# Altium schematic sheet 06_LMS7002M_RF.SchDoc(06_LMS7002M_RF)
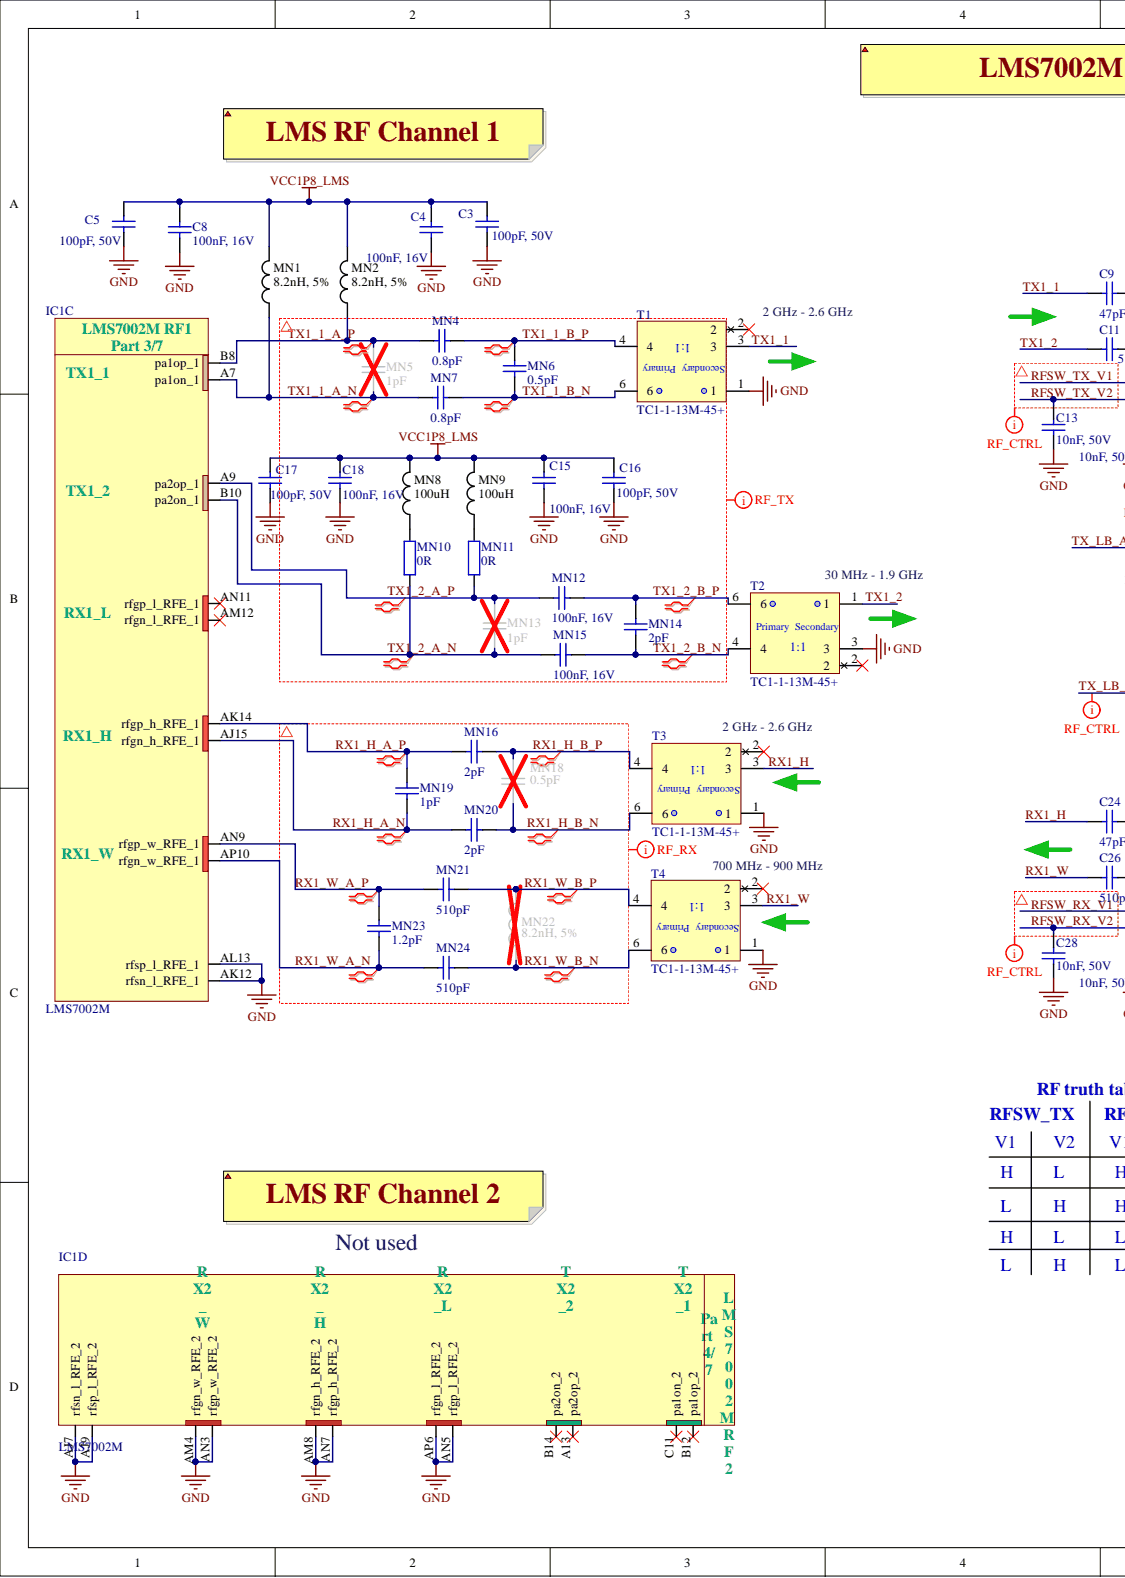
[diagram p0: 06_LMS7002M_RF.SchDoc, left]
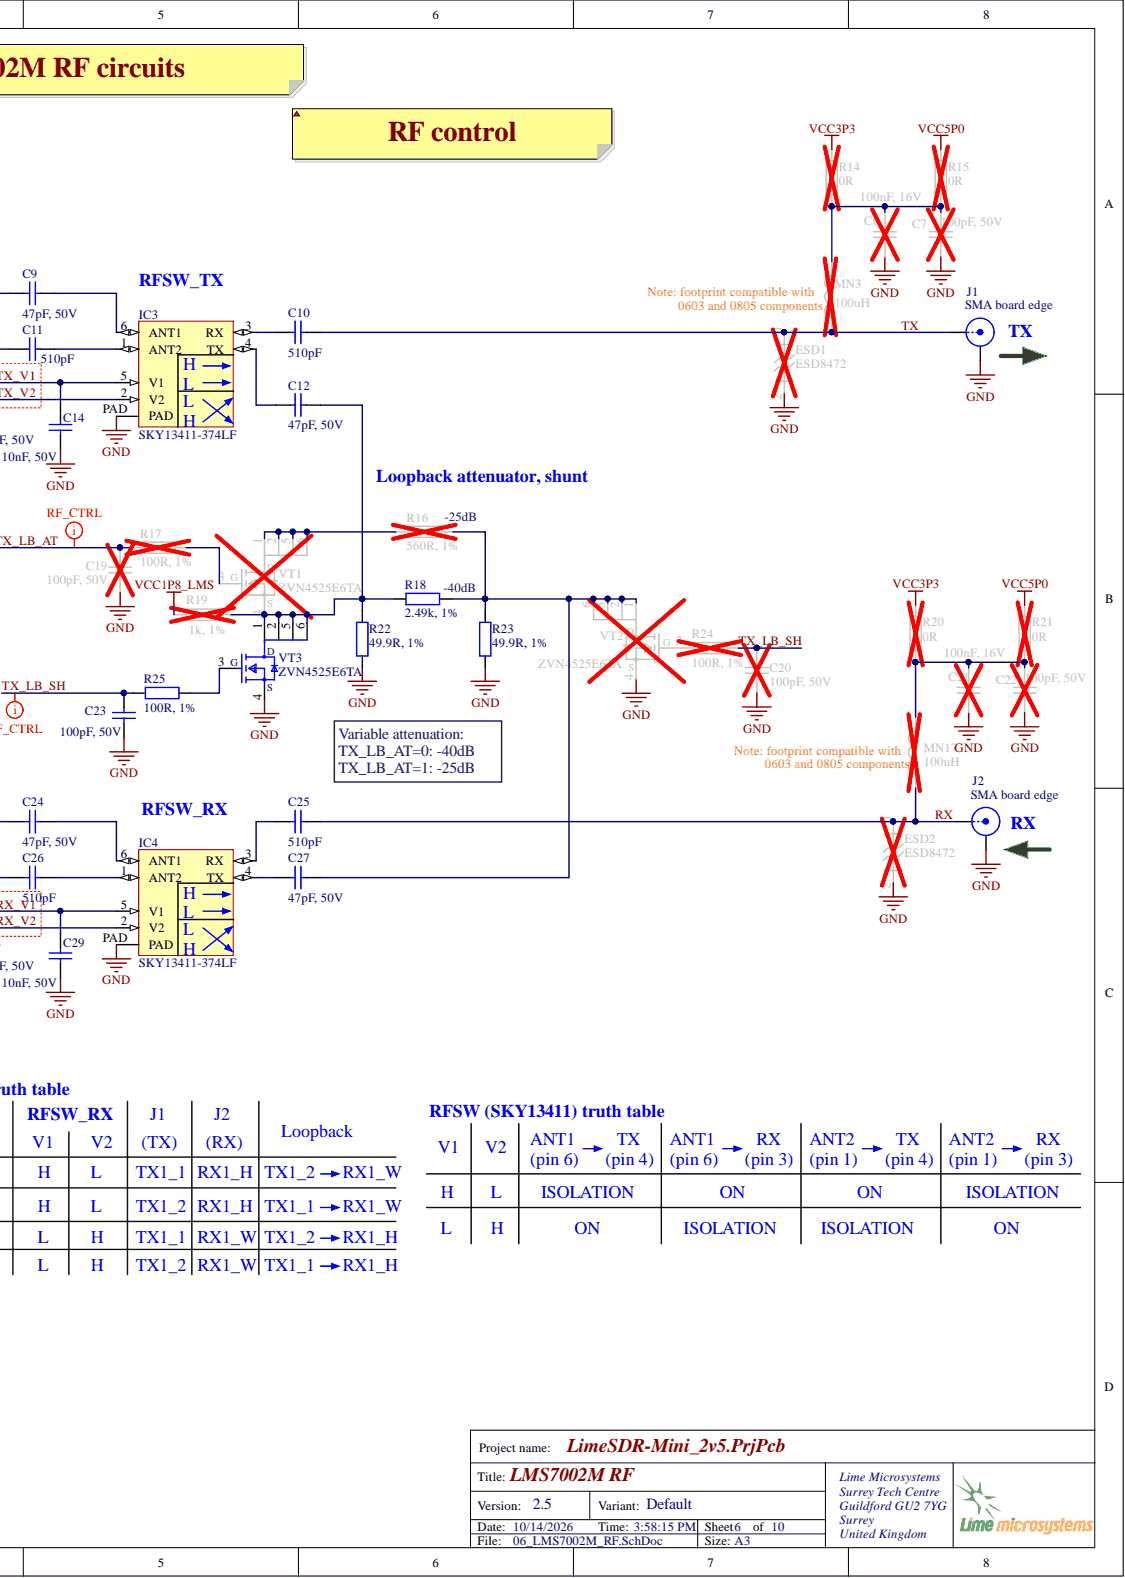
[diagram p1: 06_LMS7002M_RF.SchDoc, right]

## Parts
C3  100pF, 50V  Voltage - Rated: 50V  [CAP0402]
C4  100nF, 16V  [CAP0402]
C5  100pF, 50V  Voltage - Rated: 50V  [CAP0402]
C6  100nF, 16V  [CAP0402]
C7  100pF, 50V  Voltage - Rated: 50V  [CAP0402]
C8  100nF, 16V  [CAP0402]
C9  47pF, 50V  Voltage - Rated: 50V  [CAP0402]
C10  510pF  [CAP0402]
C11  510pF  [CAP0402]
C12  47pF, 50V  Voltage - Rated: 50V  [CAP0402]
C13  10nF, 50V  [CAP0402]
C14  10nF, 50V  Voltage Rating (DC): 50V  [CAP0402]
C15  100nF, 16V  [CAP0402]
C16  100pF, 50V  Voltage - Rated: 50V  [CAP0402]
C17  100pF, 50V  [CAP0402]
C18  100nF, 16V  [CAP0402]
C19  100pF, 50V  Voltage - Rated: 50V  [CAP0402]
C20  100pF, 50V  [CAP0402]
C21  100nF, 16V  [CAP0402]
C22  100pF, 50V  Voltage - Rated: 50V  [CAP0402]
C23  100pF, 50V  Voltage - Rated: 50V  [CAP0402]
C24  47pF, 50V  Voltage - Rated: 50V  [CAP0402]
C25  510pF  [CAP0402]
C26  510pF  [CAP0402]
C27  47pF, 50V  Voltage - Rated: 50V  [CAP0402]
C28  10nF, 50V  [CAP0402]
C29  10nF, 50V  Voltage Rating (DC): 50V  [CAP0402]
ESD1  ESD8472  [ESD8472_X3DFN2]
ESD2  ESD8472  [ESD8472_X3DFN2]
IC1  LMS7002M  [LMS7002M_v5]  (parts C,D)
IC3  SKY13411-374LF  [SKY13411-374LF]
IC4  SKY13411-374LF  [SKY13411-374LF]
J1  SMA board edge  Connector Style: SMA  [SMA_connector_board_edge_SM]
J2  SMA board edge  Connector Style: SMA  [SMA_connector_board_edge_SM]
MN1  8.2nH, 5%  [RES0402]
MN2  8.2nH, 5%  [RES0402]
MN3  100uH  [RES0805_0603]
MN4  0.8pF  [CAP0402]
MN5  1pF  [CAP0402]
MN6  0.5pF  [CAP0402]
MN7  0.8pF  [CAP0402]
MN8  100uH  [RES0805]
MN9  100uH  [RES0805]
MN10  0R  [RES0402]
MN11  0R  [RES0402]
MN12  100nF, 16V  [CAP0402]
MN13  1pF  [CAP0402]
MN14  2pF  [CAP0402]
MN15  100nF, 16V  [CAP0402]
MN16  2pF  [CAP0402]
MN17  100uH  [RES0805_0603]
MN18  0.5pF  [CAP0402]
MN19  1pF  [CAP0402]
MN20  2pF  [CAP0402]
MN21  510pF  [CAP0402]
MN22  8.2nH, 5%  [RES0402]
MN23  1.2pF  [CAP0402]
MN24  510pF  [CAP0402]
R14  0R  [RES0402]
R15  0R  [RES0402]
R16  560R, 1%  [RES0402]
R17  100R, 1%  [RES0402]
R18  2.49k, 1%  [RES0402]
R19  1k, 1%  [RES0402]
R20  0R  [RES0402]
R21  0R  [RES0402]
R22  49.9R, 1%  [RES0402]
R23  49.9R, 1%  [RES0402]
R24  100R, 1%  [RES0402]
R25  100R, 1%  [RES0402]
T1  TC1-1-13M-45+  [TC1-1-13MA+_JA4220-AL_]
T2  TC1-1-13M-45+  [TC1-1-13MA+_JA4220-AL_]
T3  TC1-1-13M-45+  [TC1-1-13MA+_JA4220-AL_]
T4  TC1-1-13M-45+  [TC1-1-13MA+_JA4220-AL_]
VT1  ZVN4525E6TA  [ZVN4525E6_NMOS_SOT23-6]
VT2  ZVN4525E6TA  [ZVN4525E6_NMOS_SOT23-6]
VT3  ZVN4525E6TA  [ZVN4525E6_NMOS_SOT23-6]

## Nets
GND: C3.2 C4.1 C5.2 C6.1 C7.2 C8.1 C13.1 C14.1 C15.1 C16.2 C17.2 C18.1 C19.2 C20.2 C21.1 C22.2 C23.2 C28.1 C29.1 ESD1.2 ESD2.2 IC1.AJ7(rfsn_l_RFE_2) IC1.AJ9(rfsp_l_RFE_2) IC1.AK12(rfsn_l_RFE_1) IC1.AL13(rfsp_l_RFE_1) IC1.AM4(rfgn_w_RFE_2) IC1.AM8(rfgn_h_RFE_2) IC1.AN3(rfgp_w_RFE_2) IC1.AN5(rfgp_l_RFE_2) IC1.AN7(rfgp_h_RFE_2) IC1.AP6(rfgn_l_RFE_2) IC3.PAD IC4.PAD J1.2 J2.2 R22.1 R23.1 T1.1 T2.3 T3.1 T4.1 VT2.4 VT3.4
VCC1P8_LMS: C3.1 C4.2 C5.1 C8.2 C15.2 C16.1 C17.1 C18.2 MN1.2 MN2.2 MN8.2 MN9.2 R19.2
VCC3P3: R14.1 R20.1
VCC5P0: R15.1 R21.1
RFSW_RX_V1: C29.2 IC4.5(V1)
RFSW_RX_V2: C28.2 IC4.2(V2)
RFSW_TX_V1: C14.2 IC3.5(V1)
RFSW_TX_V2: C13.2 IC3.2(V2)
RX: C25.2 ESD2.1 J2.1 MN17.1
RX1_H: C24.2 T3.3
RX1_H_A_N: IC1.AJ15(rfgn_h_RFE_1) MN19.1 MN20.2
RX1_H_A_P: IC1.AK14(rfgp_h_RFE_1) MN16.2 MN19.2
RX1_H_B_N: MN18.1 MN20.1 T3.6
RX1_H_B_P: MN16.1 MN18.2 T3.4
RX1_W: C26.2 T4.3
RX1_W_A_N: IC1.AP10(rfgn_w_RFE_1) MN23.1 MN24.2
RX1_W_A_P: IC1.AN9(rfgp_w_RFE_1) MN21.2 MN23.2
RX1_W_B_N: MN22.1 MN24.1 T4.6
RX1_W_B_P: MN21.1 MN22.2 T4.4
TX: C10.2 ESD1.1 J1.1 MN3.1
TX1_1: C9.2 T1.3
TX1_1_A_N: IC1.A7(pa1on_1) MN1.1 MN5.1 MN7.2
TX1_1_A_P: IC1.B8(pa1op_1) MN2.1 MN4.1 MN5.2
TX1_1_B_N: MN6.2 MN7.1 T1.6
TX1_1_B_P: MN4.2 MN6.1 T1.4
TX1_2: C11.2 T2.1
TX1_2_A_N: IC1.B10(pa2on_1) MN10.1 MN13.2 MN15.1
TX1_2_A_P: IC1.A9(pa2op_1) MN11.1 MN12.2 MN13.1
TX1_2_B_N: MN14.1 MN15.2 T2.4
TX1_2_B_P: MN12.1 MN14.2 T2.6
TX_LB_AT: C19.1 R17.2
TX_LB_SH: C20.1 C23.1 R24.1 R25.2
NetC6_2: C6.2 C7.1 MN3.2 R14.2 R15.2
NetC9_1: C9.1 IC3.6(ANT1)
NetC10_1: C10.1 IC3.3(RX)
NetC11_1: C11.1 IC3.1(ANT2)
NetC12_1: C12.1 IC3.4(TX)
NetC12_2: C12.2 R18.1 R19.1 R22.2 VT1.4 VT3.1 VT3.2 VT3.5 VT3.6
NetC21_2: C21.2 C22.1 MN17.2 R20.2 R21.2
NetC24_1: C24.1 IC4.6(ANT1)
NetC25_1: C25.1 IC4.3(RX)
NetC26_1: C26.1 IC4.1(ANT2)
NetC27_1: C27.1 IC4.4(TX)
NetC27_2: C27.2 R16.1 R18.2 R23.2 VT2.1 VT2.2 VT2.5 VT2.6
NetMN8_1: MN8.1 MN10.2
NetMN9_1: MN9.1 MN11.2
NetR16_2: R16.2 VT1.1 VT1.2 VT1.5 VT1.6
NetR17_1: R17.1 VT1.3
NetR24_2: R24.2 VT2.3
NetR25_1: R25.1 VT3.3
N00120:
N00121:
N00122:
N00123:

Not connected: IC1 A13(pa2op_2) AM12(rfgn_l_RFE_1) AN11(rfgp_l_RFE_1) B12(pa1op_2) B14(pa2on_2) C11(pa1on_2); T1 2; T2 2; T3 2; T4 2

## Library data of the parts
C3 C5 C7 C16 C17 C19 C20 C22 C23 = Cap_0402: Part Description: 100pF ±5% 50V C0G, NP0 Ceramic Capacitor -55°C ~ 125°C Surface Mount, MLCC 0402 (1005 Metric) 0.039" L x 0.020" W (1.00mm x 0.50mm); Manufacturer Part Number 1: CC0402JRNPO9BN101; Manufacturer: Yageo; Tolerance: ±5%; Temperature Coefficient: C0G, NP0; Value: 100pF, 50V; Thickness (Max): 0.022" (0.55mm); Supplier Part Number 1: 311-1024-1-ND; Supplier 1: Digi-Key; Size / Dimension: 0.039" L x 0.020" W (1.00mm x 0.50mm); RoHS: RoHS Compliant; Ratings: -; Packaging: Cut Tape (CT); Package / Case: 0402 (1005 Metric); Operating Temperature: -55°C ~ 125°C; Mounting Type: Surface Mount, MLCC; Lead Style: -; Lead Spacing: -; Height - Seated (Max): -; Features: -; Component Kind: Standard; Category: Capacitors; Capacitance: 100pF; Applications: General Purpose; Library Name: LimeMicroAltiumLib_schLib.SchLib
  C17 C20: Voltage - Rated: 50V
C4 C6 C8 C15 C18 C21 = Cap_0402: Part Description: 0.10µF ±10% 16V X7R Ceramic Capacitor -55°C ~ 125°C Surface Mount, MLCC 0402 (1005 Metric) 0.039" L x 0.020" W (1.00mm x 0.50mm); Manufacturer Part Number 1: GRM155R71C104KA88D; Manufacturer: Murata Electronics; Type: General Purpose MLCC; Tolerance: 10 %; Temperature Coefficient / Code: +/- 15 %; Dielectric: X7R; Case Width: 0.5 mm; Case Length: 1 mm; Capacitance: 0.1 uF; Voltage Rating DC: 16 V; Value: 100nF, 16V; Termination Style: SMD/SMT; Supplier Part Number 1: 81-GRM155R71C104KA88; Supplier 1: Mouser; Standard Pack Qty: 10000; Series: GRM; RoHS - Mouser: Y; RoHS: RoHS Compliant; Reeling: True; Product Category: Low & Medium Capacitance SMD Ceramic Chip Capacitors; Product: General Type MLCCs; Packaging: Reel; Package / Case: 0402 (1005 metric); Operating Temperature Range: - 55 C to + 125 C; Minimum Order Quantity: 1; Minimum Operating Temperature: - 55 C; Maximum Operating Temperature: + 125 C; Manufacturer Part Number: GRM155R71C104KA88D; Component Kind: Standard; Category: Multilayer Ceramic Capacitors MLCC - SMD/SMT; Case Height: 0.5 mm; Case Code - mm: 1005; Case Code - in: 0402; Brand: Murata Electronics; Library Name: LimeMicroAltiumLib_schLib.SchLib
C9 C12 C24 C27 = Cap_0402: Part Description: 47pF ±1% 50V C0G, NP0 Ceramic Capacitor -55°C ~ 125°C Surface Mount, MLCC 0402 (1005 Metric) 0.039" L x 0.020" W (1.00mm x 0.50mm); Manufacturer Part Number 1: GRM1555C1H470FA01D; Manufacturer: TDK Corporation; Tolerance: ±1%; Temperature Coefficient: C0G, NP0; Value: 47pF, 50V; Thickness (Max): 0.022" (0.55mm); Supplier Part Number 1: 490-6241-1-ND; Supplier 1: Digi-Key; Size / Dimension: 0.039" L x 0.020" W (1.00mm x 0.50mm); RoHS: RoHS Compliant; Ratings: -; Packaging: Cut Tape (CT); Package / Case: 0402 (1005 Metric); Operating Temperature: -55°C ~ 125°C; Mounting Type: Surface Mount, MLCC; Lead Style: -; Lead Spacing: -; Height - Seated (Max): -; Features: -; Component Kind: Standard; Category: Capacitors; Capacitance: 47pF; Applications: General Purpose; Library Name: LimeMicroAltiumLib_schLib.SchLib
C10 C11 C25 C26 MN21 MN24 = Cap_0402: Part Description: 510pF ±5% 50V C0G, NP0 Ceramic Capacitor -55°C ~ 125°C Surface Mount, MLCC 0402 (1005 Metric) 0.039" L x 0.020" W (1.00mm x 0.50mm); Manufacturer Part Number 1: GRM1555C1H511JA01D; Manufacturer: Murata Electronics North America; Voltage - Rated: 50V; Tolerance: ±5%; Temperature Coefficient: C0G, NP0; Value: 510pF; Thickness (Max): 0.022" (0.55mm); Supplier Part Number 1: 490-3237-1-ND; Supplier 1: Digi-Key; Size / Dimension: 0.039" L x 0.020" W (1.00mm x 0.50mm); RoHS: RoHS Compliant; Ratings: -; Packaging: Cut Tape (CT); Package / Case: 0402 (1005 Metric); Operating Temperature: -55°C ~ 125°C; Mounting Type: Surface Mount, MLCC; Lead Style: -; Lead Spacing: -; Height - Seated (Max): -; Features: -; Component Kind: Standard; Category: Capacitors; Capacitance: 510pF; Applications: General Purpose; Library Name: LimeMicroAltiumLib_schLib.SchLib
C13 C14 C28 C29 = Cap_0402: Part Description: 10000pF ±10% 50V Ceramic Capacitor X7R 0402 (1005 Metric); Manufacturer Part Number 1: GRM155R71H103KA88D; Manufacturer: Murata; Voltage: 25V; Tolerance: 10%; Material: Ceramic; Dielectric: X7R; Width: 0.02inch; Voltage Rating: 50V; value: 10nF, 50V; Thickness: 0.022inch; Termination: SMD/SMT; Supplier Part Number 1: 490-4516-1-ND; Supplier 1: Digi-Key; Stock 1: 8941817; RoHS: Yes; REACH SVHC: No SVHC; Radiation Hardening: No; Pricing 1: 1=0,10000, 10=0,03800, 100=0,01690, 500=0,01208, 1000=0,00949, 2500=0,00862, 5000=0,00794 (USD); Packaging: Tape and Reel; Package Quantity: 1; Number of Pins: 2; Mount: Surface Mount; Min Operating Temperature: -55°C; Max Operating Temperature: 125°C; Length: 1mm; Lead Free: Lead Free; Height: 0.5mm; Depth: 0.5mm; Component Kind: Standard; Case Code (Metric): 1005; Case Code (Imperial): 0402; Case/Package: 0402; Capacitance: 10nF; Library Name: LimeFPGAboard_schLib.SchLib
  C13 C28: Voltage Rating (DC): 50V
ESD1 ESD2 = ESD8472: Part Description: TVS DIODE 5.3VWM 14VC X3DFN2; Manufacturer Part Number 1: ESD8472MUT5G; Manufacturer: ON Semiconductor; Voltage - Reverse Standoff (Typ): 5.3V (Max); Voltage - Clamping (Max) @ Ipp: 14V; Voltage - Breakdown (Min): 7V; Power Line Protection: No; Current - Peak Pulse (10/1000µs): 3A (8/20µs); Capacitance @ Frequency: 0.2pF @ 1MHz; Type: Zener; Supplier Part Number 1: ESD8472MUT5GOSCT-ND; Supplier Device Package: 2-X3DFN (0.62x0.32); Supplier 1: Digi-Key; Stock 1: 2295; RoHS: RoHS Compliant; Pricing 1: 1=0.39, 10=0.315, 100=0.2142, 500=0.16068, 1000=0.12051 (USD); Power - Peak Pulse: -; Part Status: Active; Packaging: Cut Tape (CT); Package / Case: 2-XFDFN; Operating Temperature: -55°C ~ 150°C (TJ); Mounting Type: Surface Mount; ComponentLink1URL: http://www.onsemi.com/pub/Collateral/ESD8472-D.PDF; ComponentLink1Description: http://www.onsemi.com/pub/Collateral/ESD8472-D.PDF; Component Kind: Standard; Category: Circuit Protection; Applications: Automotive, RF Antenna; Library Name: LimeMicroAltiumLib_schLib.SchLib
IC1 = LMS7002Mr4: Schematic symbol revision 4. Default footprint - 0.31mm pad; Part Description: IC FPRF Transceiver LMS7002M; 261 pin aQFN; Manufacturer: Lime Microsystems; Supplier Part Number 1: LMS7002M; Supplier 1: Lime Microsystems; Manufacturer Part Number 1: LMS7002M; Library Name: LimeMicroAltiumLib_schLib_r04.SchLib; Component Kind: Standard
IC3 IC4 = SKY13411-374LF: Part Description: RF Switch IC 802.11a/b/g/n DPDT 50 Ohm 6-MLPD (1.5x1.5); Manufacturer: Skyworks Solutions Inc.; Topology: Reflective; RF Type: 802.11a/b/g/n; P1dB: 30dBm (typ) IP1dB; Isolation @ Frequency: 14dB @ 6GHz (typ); Insertion Loss @ Frequency: 0.9dB @ 6GHz; Impedance: 50 Ohm; IIP3: 50dBm (typ); Frequency - Lower: 100MHz; Circuit: DPDT; Voltage - Supply: -; Supplier Part Number 1: 863-1455-1-ND; Supplier Device Package: 6-MLPD (1.5x1.5); Supplier 1: Digi-Key; Stock 1: 18782; RoHS: RoHS Compliant; Pricing 1: 1=0.88, 10=0.782, 100=0.6099, 500=0.50382, 1000=0.39776 (USD); Part Status: Active; Packaging: Cut Tape (CT); Package / Case: 6-XFDFN Exposed Pad; Operating Temperature: -40°C ~ 85°C; Manufacturer Part Number 1: SKY13411-374LF; Features: -; ComponentLink1URL: http://www.skyworksinc.com/uploads/documents/201665B.pdf; ComponentLink1Description: http://www.skyworksinc.com/uploads/documents/201665B.pdf; Component Kind: Standard; Category: RF/IF and RFID; Library Name: LimeMicroAltiumLib_schLib.SchLib
J1 J2 = RF_IF_connector: CONN SMA JACK STR 50OHM EDGE MNT; Part Description: SMA Connector Jack, Female Socket Board Edge, End Launch Solder; Manufacturer Part Number 1: CON-SMA-EDGE-S; Manufacturer: RF Solutions; Shield Termination: Solder; Connector Type: Jack, Female Socket; Voltage Rating: -; Supplier Part Number 1: CON-SMA-EDGE-S-ND; Supplier 1: Digi-Key; Stock 1: 1751; RoHS: RoHS Compliant; Pricing 1: 1=2.1 (USD); Part Status: Active; Packaging: -; Operating Temperature: -; Mounting Type: Board Edge, End Launch; Mounting Feature: -; Mating Cycles: -; Insertion Loss: -; Ingress Protection: -; Includes: -; Impedance: -; Housing Color: -; Frequency - Max: -; Features: -; Fastening Type: Threaded; Dielectric Material: -; Contact Termination: Solder; ComponentLink1URL: http://media.digikey.com/pdf/Data%20Sheets/RF%20Solutions%20PDFs/CON-SMA-EDGE.pdf; ComponentLink1Description: http://media.digikey.com/pdf/Data%20Sheets/RF%20Solutions%20PDFs/CON-SMA-EDGE.pdf; Component Kind: Standard; Center Contact Plating: -; Center Contact Material: -; Category: Connectors, Interconnects; Cable Group: -; Body Material: -; Body Finish: -; Library Name: LimeMicroAltiumLib_schLib.SchLib
MN1 MN2 MN22 = Inductor_0603: Part Description: Surface Mount High Frequency Inductor, 0402HP Series, 8.2 nH, ± 5%, 0402 [1005 Metric], 5.4 GHz; Manufacturer Part Number 1: 0402HP-8N2XJLU; Manufacturer: COILCRAFT; SVHC: No SVHC (16-Jun-2014); Self Resonant Frequency Min: 5.4; Inductor Construction: Wirewound; Inductor Case Style: 0402 [1005 Metric]<!--FANDB--><div class="features-and-benefits-container"></div>; Inductance: 8.2; High Frequency Inductor Case: 0402 [1005 Metric]; DC Resistance Max: 0.065; DC Current Rating: 1.5; Value: 8.2nH, 5%; Supplier Part Number 1: 2285826; Supplier 1: Farnell; RoHS: Y-EX; Product Range: COILCRAFT - 0402; Packaging: Cut Tape; Inductance Tolerance: ± 5%; Component Kind: Standard; Library Name: LimeMicroAltiumLib_schLib.SchLib
MN3 MN8 MN9 MN17 = Inductor_0603: Part Description: FIXED IND 100UH 2MA 3.1 OHM SMD; Manufacturer Part Number 1: MLF2012C101KT000; Manufacturer: TDK Corporation; Tolerance: ±10%; Shielding: Shielded; Q @ Freq: 25 @ 1MHz; Material - Core: Ferrite; Inductance: 100µH; Frequency - Test: 1MHz; Frequency - Self Resonant: 7MHz; DC Resistance (DCR): 3.1 Ohm Max; Current Rating: 2mA; Value: 100uH; Type: Multilayer; Supplier Part Number 1: 445-1071-1-ND; Supplier Device Package: 0805 (2012 Metric); Supplier 1: Digi-Key; Size / Dimension: 0.079" L x 0.049" W (2.00mm x 1.25mm); RoHS: RoHS Compliant; Packaging: Cut Tape (CT); Package / Case: 0805 (2012 Metric); Operating Temperature: -40°C ~ 85°C; Mounting Type: Surface Mount; Height - Seated (Max): 0.057" (1.45mm); Current - Saturation: -; Component Kind: Standard; Category: Inductors, Coils, Chokes; Library Name: LimeMicroAltiumLib_schLib.SchLib
MN4 MN7 = Cap_0402: Part Description: 0.80pF ±0.1pF 50V C0G, NP0 Ceramic Capacitor -55°C ~ 125°C Surface Mount, MLCC 0402 (1005 Metric) 0.039" L x 0.020" W (1.00mm x 0.50mm); Manufacturer Part Number 1: GRM1555C1HR80BA01D; Manufacturer: Murata Electronics North America; Voltage - Rated: 50V; Tolerance: ±0.1pF; Temperature Coefficient: C0G, NP0; Capacitance: 0.80pF; Value: 0.8pF; Thickness (Max): 0.022" (0.55mm); Supplier Part Number 1: 490-6269-1-ND; Supplier 1: Digi-Key; Size / Dimension: 0.039" L x 0.020" W (1.00mm x 0.50mm); RoHS: RoHS Compliant; Ratings: -; Packaging: Cut Tape (CT); Package / Case: 0402 (1005 Metric); Operating Temperature: -55°C ~ 125°C; Mounting Type: Surface Mount, MLCC; Lead Style: -; Lead Spacing: -; Height - Seated (Max): -; Features: -; Component Kind: Standard; Category: Capacitors; Applications: General Purpose; Library Name: LimeMicroAltiumLib_schLib.SchLib
MN5 MN13 MN19 = Cap_0402: Part Description: 1pF ±0.1pF 50V C0G, NP0 Ceramic Capacitor -55°C ~ 125°C Surface Mount, MLCC 0402 (1005 Metric) 0.039" L x 0.020" W (1.00mm x 0.50mm); Manufacturer Part Number 1: C1005C0G1H010B050BA; Manufacturer: TDK Corporation; Voltage - Rated: 50V; Tolerance: ±0.1pF; Temperature Coefficient: C0G, NP0; Value: 1pF; Thickness (Max): 0.022" (0.55mm); Supplier Part Number 1: 445-4854-6-ND; Supplier 1: Digi-Key; Size / Dimension: 0.039" L x 0.020" W (1.00mm x 0.50mm); RoHS: RoHS Compliant; Ratings: -; Packaging: Digi-Reel®; Package / Case: 0402 (1005 Metric); Operating Temperature: -55°C ~ 125°C; Mounting Type: Surface Mount, MLCC; Lead Style: -; Lead Spacing: -; Height - Seated (Max): -; Features: -; Component Kind: Standard; Category: Capacitors; Capacitance: 1pF; Applications: General Purpose; Library Name: LimeMicroAltiumLib_schLib.SchLib
MN6 MN18 = Cap_0402: Part Description: 0.50pF ±0.25pF 50V C0G, NP0 Ceramic Capacitor -55°C ~ 125°C Surface Mount, MLCC 0402 (1005 Metric) 0.039" L x 0.020" W (1.00mm x 0.50mm); Manufacturer Part Number 1: CC0402CRNPO9BNR50; Manufacturer: Yageo; Voltage - Rated: 50V; Tolerance: ±0.25pF; Temperature Coefficient: C0G, NP0; Capacitance: 0.50pF; Value: 0.5pF; Thickness (Max): 0.022" (0.55mm); Supplier Part Number 1: 311-1001-1-ND; Supplier 1: Digi-Key; Size / Dimension: 0.039" L x 0.020" W (1.00mm x 0.50mm); RoHS: RoHS Compliant; Ratings: -; Packaging: Cut Tape (CT); Package / Case: 0402 (1005 Metric); Operating Temperature: -55°C ~ 125°C; Mounting Type: Surface Mount, MLCC; Lead Style: -; Lead Spacing: -; Height - Seated (Max): -; Features: -; Component Kind: Standard; Category: Capacitors; Applications: General Purpose; Library Name: LimeMicroAltiumLib_schLib.SchLib
MN10 MN11 R14 R15 R20 R21 = Res_0402: Part Description: RES SMD 0.0OHM JUMPER 1/16W 0402; Manufacturer Part Number 1: RC0402JR-070RL; Manufacturer: Yageo; Tolerance: Jumper; Resistance (Ohms): 0.0; Power (Watts): 0.063W, 1/16W; Value: 0R; Temperature Coefficient: -; Supplier Part Number 1: 311-0.0JRTR-ND; Supplier Device Package: 0402; Supplier 1: Digi-Key; Size / Dimension: 0.039" L x 0.020" W (1.00mm x 0.50mm); RoHS: RoHS Compliant; Packaging: Tape & Reel (TR); Package / Case: 0402 (1005 Metric); Operating Temperature: -55°C ~ 155°C; Number of Terminations: 2; Height: 0.016" (0.40mm); Features: Moisture Resistant; Composition: Thick Film; Component Kind: Standard; Category: Resistors; Library Name: LimeFPGAboard_schLib.SchLib
MN12 MN15 = Cap_0402: Part Description: 0.10µF ±10% 16V X7R Ceramic Capacitor -55°C ~ 125°C Surface Mount, MLCC 0402 (1005 Metric) 0.039" L x 0.020" W (1.00mm x 0.50mm); Manufacturer Part Number 1: GRM155R71C104KA88D; Manufacturer: Murata Electronics; Tolerance: 10 %; Temperature Coefficient / Code: +/- 15 %; Dielectric: X7R; Case Width: 0.5 mm; Case Length: 1 mm; Capacitance: 0.1 uF; Voltage Rating DC: 16 V; Value: 100nF, 16V; Type: General Purpose MLCC; Termination Style: SMD/SMT; Supplier Part Number 1: 81-GRM155R71C104KA88; Supplier 1: Mouser; Standard Pack Qty: 10000; Series: GRM; RoHS - Mouser: Y; RoHS: RoHS Compliant; Reeling: True; Product Category: Low & Medium Capacitance SMD Ceramic Chip Capacitors; Product: General Type MLCCs; Packaging: Reel; Package / Case: 0402 (1005 metric); Operating Temperature Range: - 55 C to + 125 C; Minimum Order Quantity: 1; Minimum Operating Temperature: - 55 C; Maximum Operating Temperature: + 125 C; Manufacturer Part Number: GRM155R71C104KA88D; Component Kind: Standard; Category: Multilayer Ceramic Capacitors MLCC - SMD/SMT; Case Height: 0.5 mm; Case Code - mm: 1005; Case Code - in: 0402; Brand: Murata Electronics; Library Name: LimeMicroAltiumLib_schLib.SchLib
MN14 MN16 MN20 = Cap_0402: Part Description: SMD Multilayer Ceramic Capacitor, U Series, 2 pF, ± 0.1pF, C0G / NP0, 50 V, 0402 [1005 Metric]; Manufacturer Part Number 1: 04025U2R0BAT2A; Manufacturer: AVX; Voltage Rating: 50; SVHC: No SVHC (17-Dec-2014); Product Range: U Series; Dielectric Characteristic: C0G / NP0; Ceramic Capacitor Case: 0402 [1005 Metric]; Capacitance Tolerance ±: ± 0.1; Capacitance: 2; Value: 2pF; Supplier Part Number 1: 2332536; Supplier 1: Farnell; RoHS: YES; Packaging: Cut Tape; Operating Temperature Min: -55; Operating Temperature Max: 125; MSL: MSL 1 - Unlimited; Component Kind: Standard; Capacitance Tolerance: ± 0.1; Library Name: LimeMicroAltiumLib_schLib.SchLib
MN23 = Cap_0402: Part Description: '1.2pF ±0.25pF 50V C0G, NP0 Ceramic Capacitor -55°C ~ 125°C Surface Mount, MLCC 0402 (1005 Metric) 0.039" L x 0.020" W (1.00mm x 0.50mm); Manufacturer Part Number 1: GRM1555C1H1R2CA01D; Manufacturer: Murata Electronics North America; Voltage - Rated: 50V; Tolerance: ±0.25pF; Temperature Coefficient: C0G, NP0; Value: 1.2pF; Thickness (Max): 0.022" (0.55mm); Supplier Part Number 1: 490-5927-1-ND; Supplier 1: Digi-Key; Size / Dimension: 0.039" L x 0.020" W (1.00mm x 0.50mm); RoHS: RoHS Compliant; Ratings: -; Packaging: Cut Tape (CT); Package / Case: 0402 (1005 Metric); Operating Temperature: -55°C ~ 125°C; Mounting Type: Surface Mount, MLCC; Lead Style: -; Lead Spacing: -; Height - Seated (Max): -; Features: -; Component Kind: Standard; Category: Capacitors; Capacitance: 1.2pF; Applications: General Purpose; Library Name: LimeMicroAltiumLib_schLib.SchLib
R16 = Res_0402: Part Description: RES SMD 560 OHM 1% 1/16W 0402; Manufacturer Part Number 1: CRCW0402560RFKED; Manufacturer: Vishay Dale; Temperature Coefficient: ±100ppm/°C; Resistance (Ohms): 560; Power (Watts): 0.063W, 1/16W; Tolerance: ±1%; Supplier Part Number 1: 541-560LCT-ND; Supplier Device Package: 0402 (1005 Metric); Supplier 1: Digi-Key; Size / Dimension: 0.039" L x 0.020" W (1.00mm x 0.50mm); RoHS: RoHS Compliant; Packaging: Cut Tape (CT); Package / Case: 0402 (1005 Metric); Operating Temperature: -55°C ~ 155°C; Number of Terminations: 2; Height: 0.016" (0.40mm); Features: Automotive AEC-Q200; Composition: Thick Film; Component Kind: Standard; Category: Resistors; Library Name: LimeMicroAltiumLib_schLib.SchLib
R17 R24 R25 = Res_0402: Part Description: RES SMD 100 OHM 1% 1/16W 0402; Manufacturer Part Number 1: RMCF0402FT100R; Manufacturer: Stackpole Electronics Inc.; Temperature Coefficient: ±100ppm/°C; Value: 100R, 1%; Tolerance: ±1%; Supplier Part Number 1: RMCF0402FT100RDKR-ND; Supplier 1: Digi-Key; Package / Case: 0402 (1005 Metric); Composition: Thick Film; Component Kind: Standard; Category: Resistors; Library Name: LimeFPGAboard_schLib.SchLib
R18 = Res_0402: Part Description: RES SMD 2.49K OHM 1% 1/16W 0402; Manufacturer Part Number 1: RC0402FR-072K49L; Manufacturer: Yageo; Temperature Coefficient: ±100ppm/°C; Power (Watts): 0.063W, 1/16W; Value: 2.49k, 1%; Tolerance: ±1%; Supplier Part Number 1: 311-2.49KLRDKR-ND; Supplier Device Package: 0402; Supplier 1: Digi-Key; Size / Dimension: 0.039" L x 0.020" W (1.00mm x 0.50mm); RoHS: RoHS Compliant; Resistance (Ohms): 2.49k; Packaging: Digi-Reel®; Package / Case: 0402 (1005 Metric); Operating Temperature: -55°C ~ 155°C; Number of Terminations: 2; Height: 0.016" (0.40mm); Features: Moisture Resistant; Composition: Thick Film; Component Kind: Standard; Category: Resistors; Library Name: LimeMicroAltiumLib_schLib.SchLib
R19 = Res_0402: Part Description: RES SMD 1K OHM 1% 1/16W 0402; Manufacturer Part Number 1: RMCF0402FT1K00; Manufacturer: Stackpole Electronics Inc; Temperature Coefficient: ±100ppm/°C; Power (Watts): 0.063W, 1/16W; Value: 1k, 1%; Tolerance: ±1%; Supplier Part Number 1: RMCF0402FT1K00TR-ND; Supplier Device Package: 0402; Supplier 1: Digi-Key; Size / Dimension: 0.039" L x 0.020" W (1.00mm x 0.50mm); RoHS: RoHS Compliant; Resistance (Ohms): 1k; Packaging: Tape & Reel (TR); Package / Case: 0402 (1005 Metric); Operating Temperature: -55°C ~ 155°C; Number of Terminations: 2; Height: 0.016" (0.40mm); Features: -; Composition: Thick Film; Component Kind: Standard; Category: Resistors; Library Name: LimeMicroAltiumLib_schLib.SchLib
R22 R23 = Res_0402: Part Description: RES SMD 49.9 OHM 1% 1/16W 0402; Manufacturer Part Number 1: RC1005F49R9CS; Manufacturer: Samsung Electro-Mechanics America, Inc.; Temperature Coefficient: ±100ppm/°C; Resistance (Ohms): 49.9; Power (Watts): 0.063W, 1/16W; Value: 49.9R, 1%; Tolerance: ±1%; Supplier Part Number 1: 1276-3469-6-ND; Supplier Device Package: 0402 (1005 Metric); Supplier 1: Digi-Key; Size / Dimension: 0.039" L x 0.020" W (1.00mm x 0.50mm); RoHS: RoHS Compliant; Packaging: Digi-Reel®; Package / Case: 0402 (1005 Metric); Operating Temperature: -55°C ~ 155°C; Number of Terminations: 2; Height: 0.016" (0.40mm); Features: -; Composition: Thick Film; Component Kind: Standard; Category: Resistors; Library Name: LimeMicroAltiumLib_schLib.SchLib
T1-T4 = TC1-1-13M+: Part Description: RF balun; 1:1 ratio; 3 dB bandwidth: 0.3 MHz to 3.5 GHz; 3.8mm x 3.8mm; Manufacturer: Minicircuits; Supplier Part Number 1: TC1-1-13M-45+; Supplier 1: Minicircuits; Manufacturer Part Number 1: TC1-1-13M-45+; Component Kind: Standard; Library Name: LimeMicroAltiumLib_schLib.SchLib
VT1-VT3 = ZVN4525E6_NMOS: Part Description: N-Channel 250 V 230mA (Ta) 1.1W (Ta) Surface Mount SOT-23-6; Manufacturer: Diodes Zetex; Voltage Rating (DC): 250V; Turn-On Delay Time: 1.25ns; Turn-Off Delay Time: 11.4ns; Threshold Voltage: 1.4V; Rise Time: 1.7ns; Rds On Max: 8.5R; Power Dissipation: 1.1W; Polarity: N-CHANNEL; Number of Elements: 1; Input Capacitance: 72pF; Gate to Source Voltage (Vgs): 40V; Element Configuration: Single; Drain to Source Resistance: 9.5R; Current Rating: 230mA; Width: 1.8mm; Weight: 0.000529oz; Supplier Part Number 1: 31-ZVN4525E6TACT-ND; Supplier 1: Digi-Key; Stock 1: 1399; Series: -; RoHS Compliant: Yes; RoHS: Yes; REACH SVHC: No SVHC; Radiation Hardening: No; Pricing 1: 1=0,91000, 10=0,81200, 100=0,63300, 500=0,52292, 1000=0,41283 (USD); Packaging: Tape and Reel; Package Quantity: 3000; On-State Resistance: 8.5R; Number of Pins: 6; Number of Channels: 1; Nominal Vgs: 1.4V; Mount: Surface Mount; Min Operating Temperature: -55°C; Max Power Dissipation: 1.1W; Max Operating Temperature: 150°C; Manufacturer Part Number 1: ZVN4525E6TA; Length: 3.1mm; Lead Free: Lead Free; Height: 1.3mm; Fall Time: 1.7ns; Drain to Source Voltage (Vdss): 250V; Drain to Source Breakdown Voltage: 250V; Drain-source On Resistance-Max: 8.5R; Continuous Drain Current (ID): 230mA; ComponentLink3URL: https://datasheet.ciiva.com/16504/zvn4525e6-16504983.pdf?src-supplier=Future+Electronics; ComponentLink3Description: https://datasheet.ciiva.com/16504/zvn4525e6-16504983.pdf?src-supplier=Future+Electronics; ComponentLink2URL: https://datasheet.ciiva.com/25625/0900766b8104e683-25625123.pdf?src-supplier=RSComponents; ComponentLink2Description: https://datasheet.ciiva.com/25625/0900766b8104e683-25625123.pdf?src-supplier=RSComponents; ComponentLink1URL: https://datasheet.ciiva.com/935/zvn4525e6-935664.pdf?src-supplier=Digi-Key; ComponentLink1Description: https://datasheet.ciiva.com/935/zvn4525e6-935664.pdf?src-supplier=Digi-Key; Component Kind: Standard; Case/Package: SOT-23; Library Name: LimeMicroAltiumLib_schLib_r03.SchLib
